# Altium schematic sheet Stepper Motor2.SchDoc
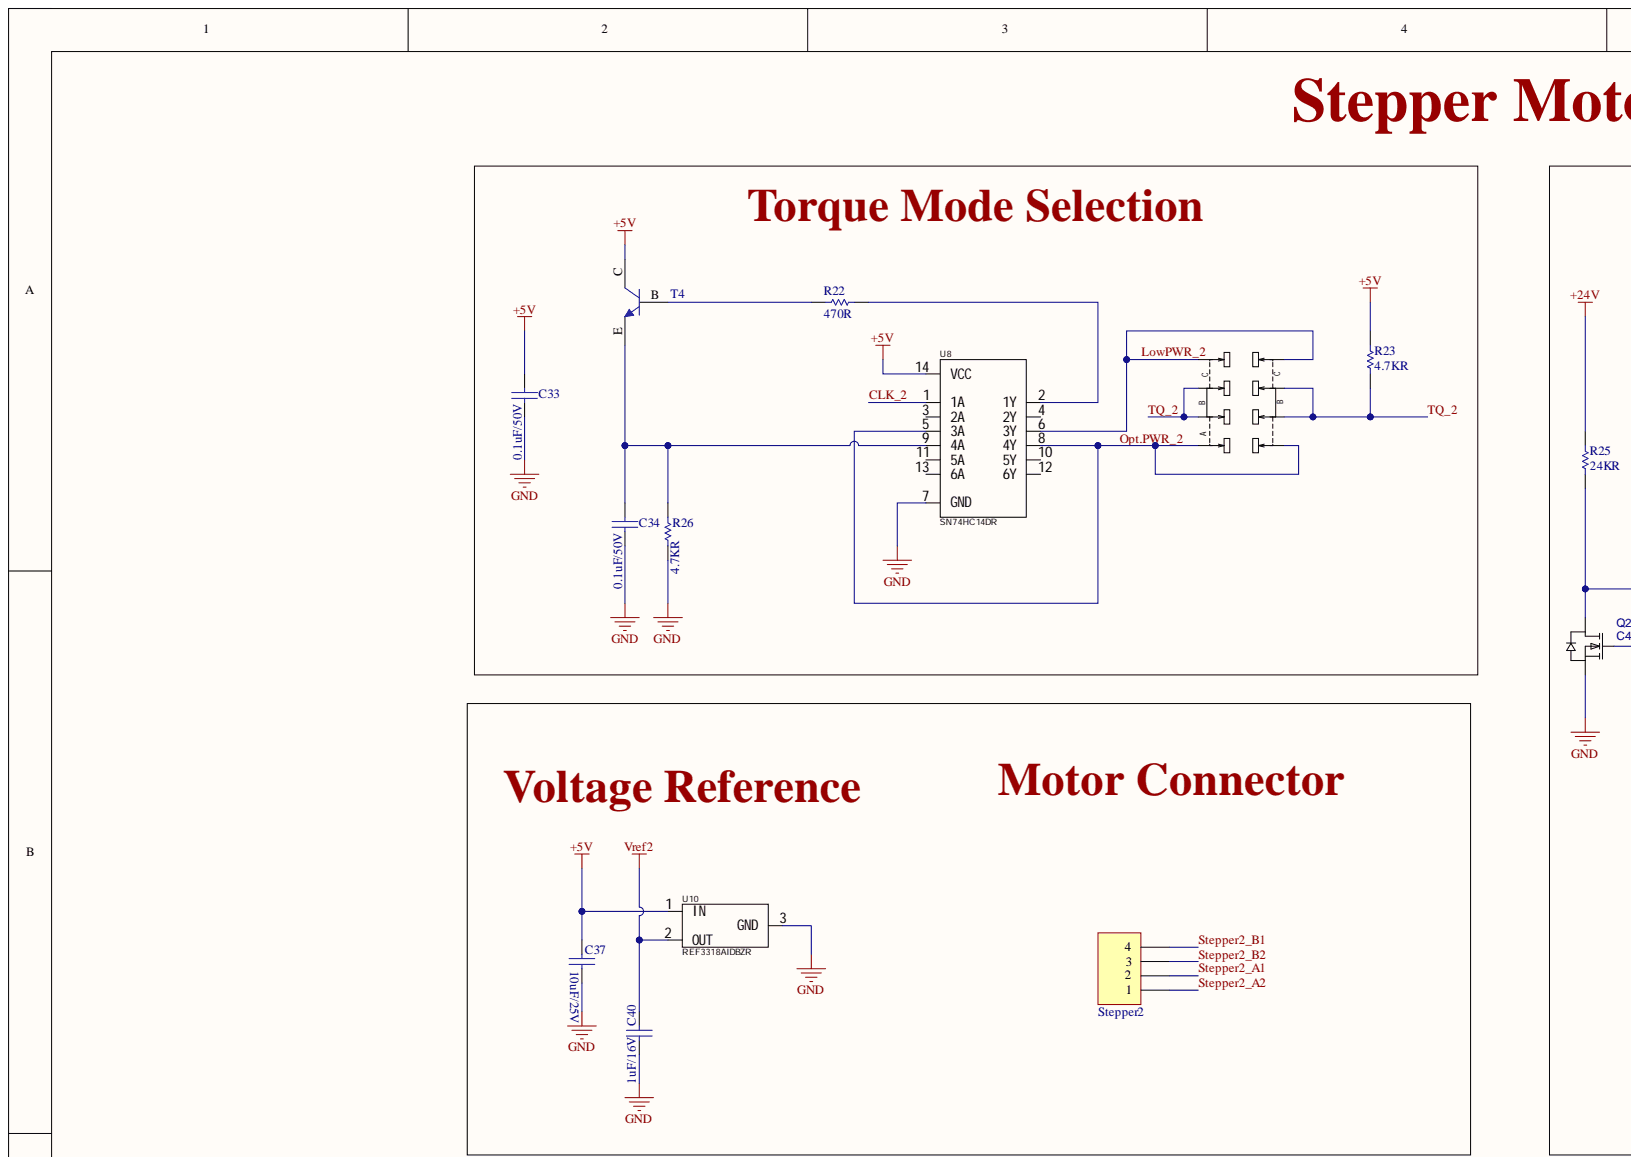
[diagram p0: Stepper Motor2.SchDoc, top left]
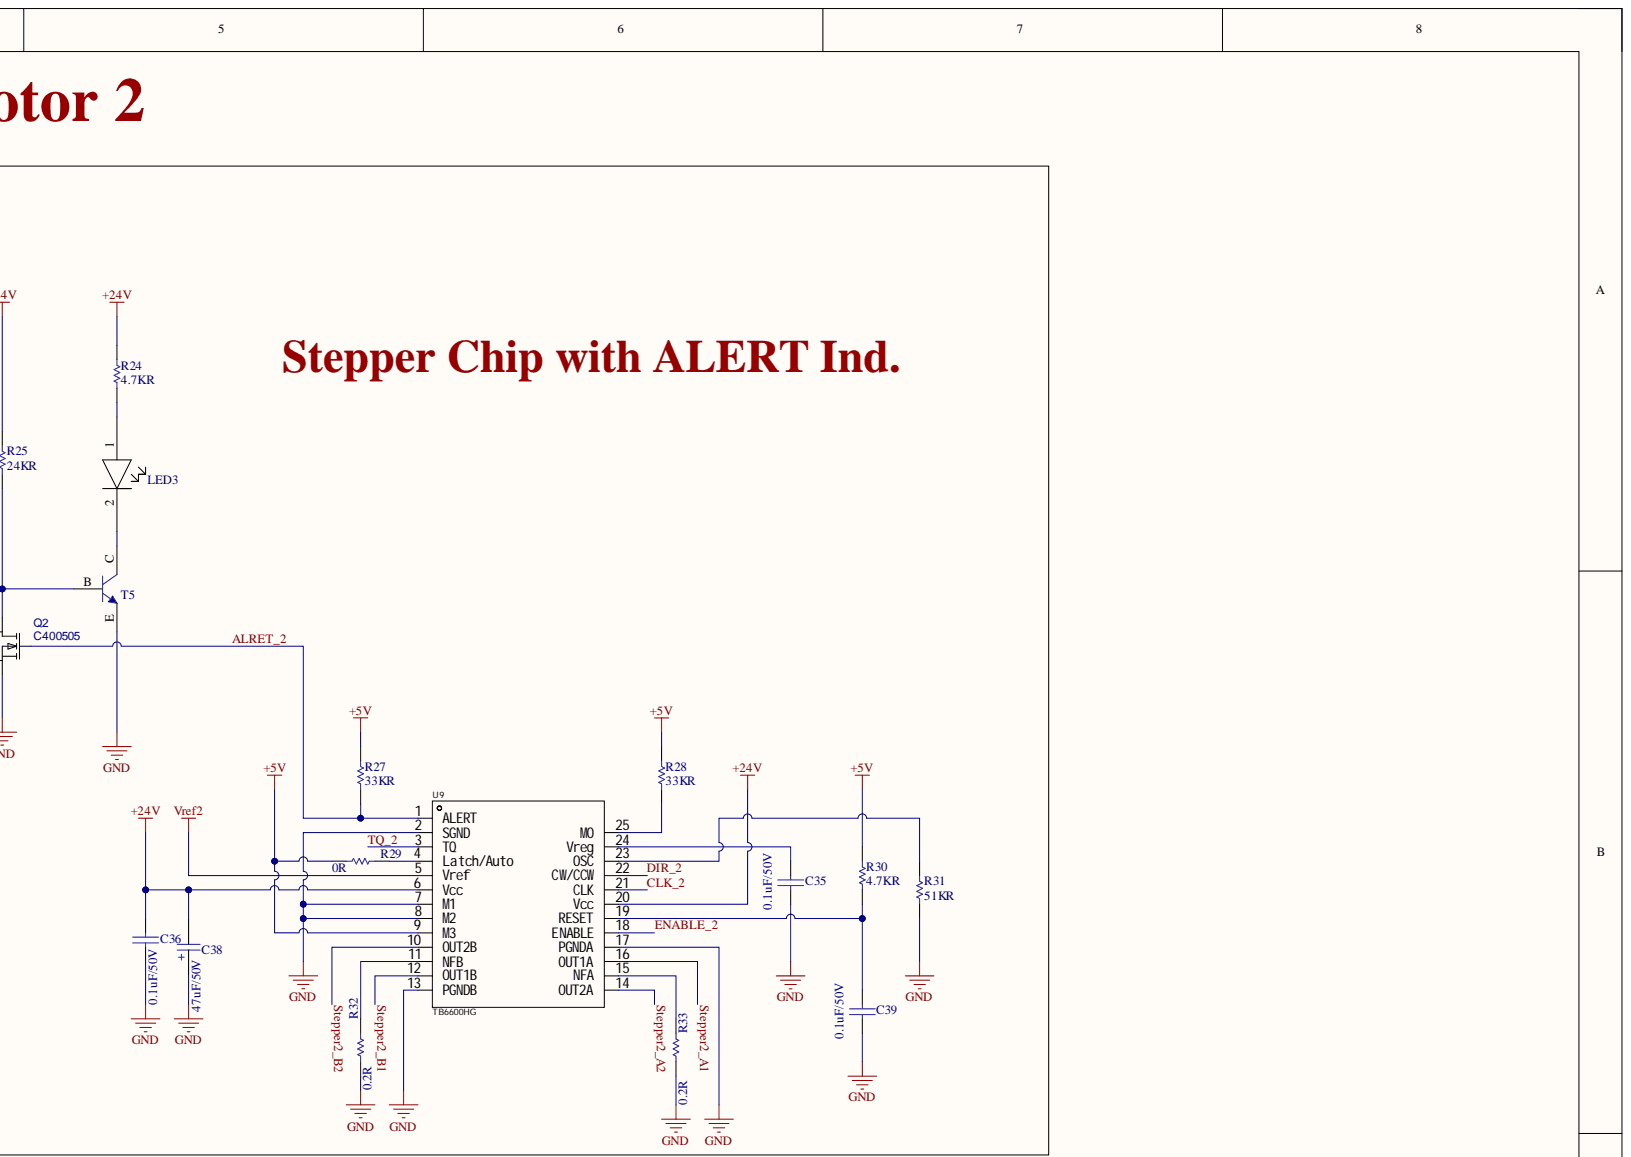
[diagram p1: Stepper Motor2.SchDoc, top right]
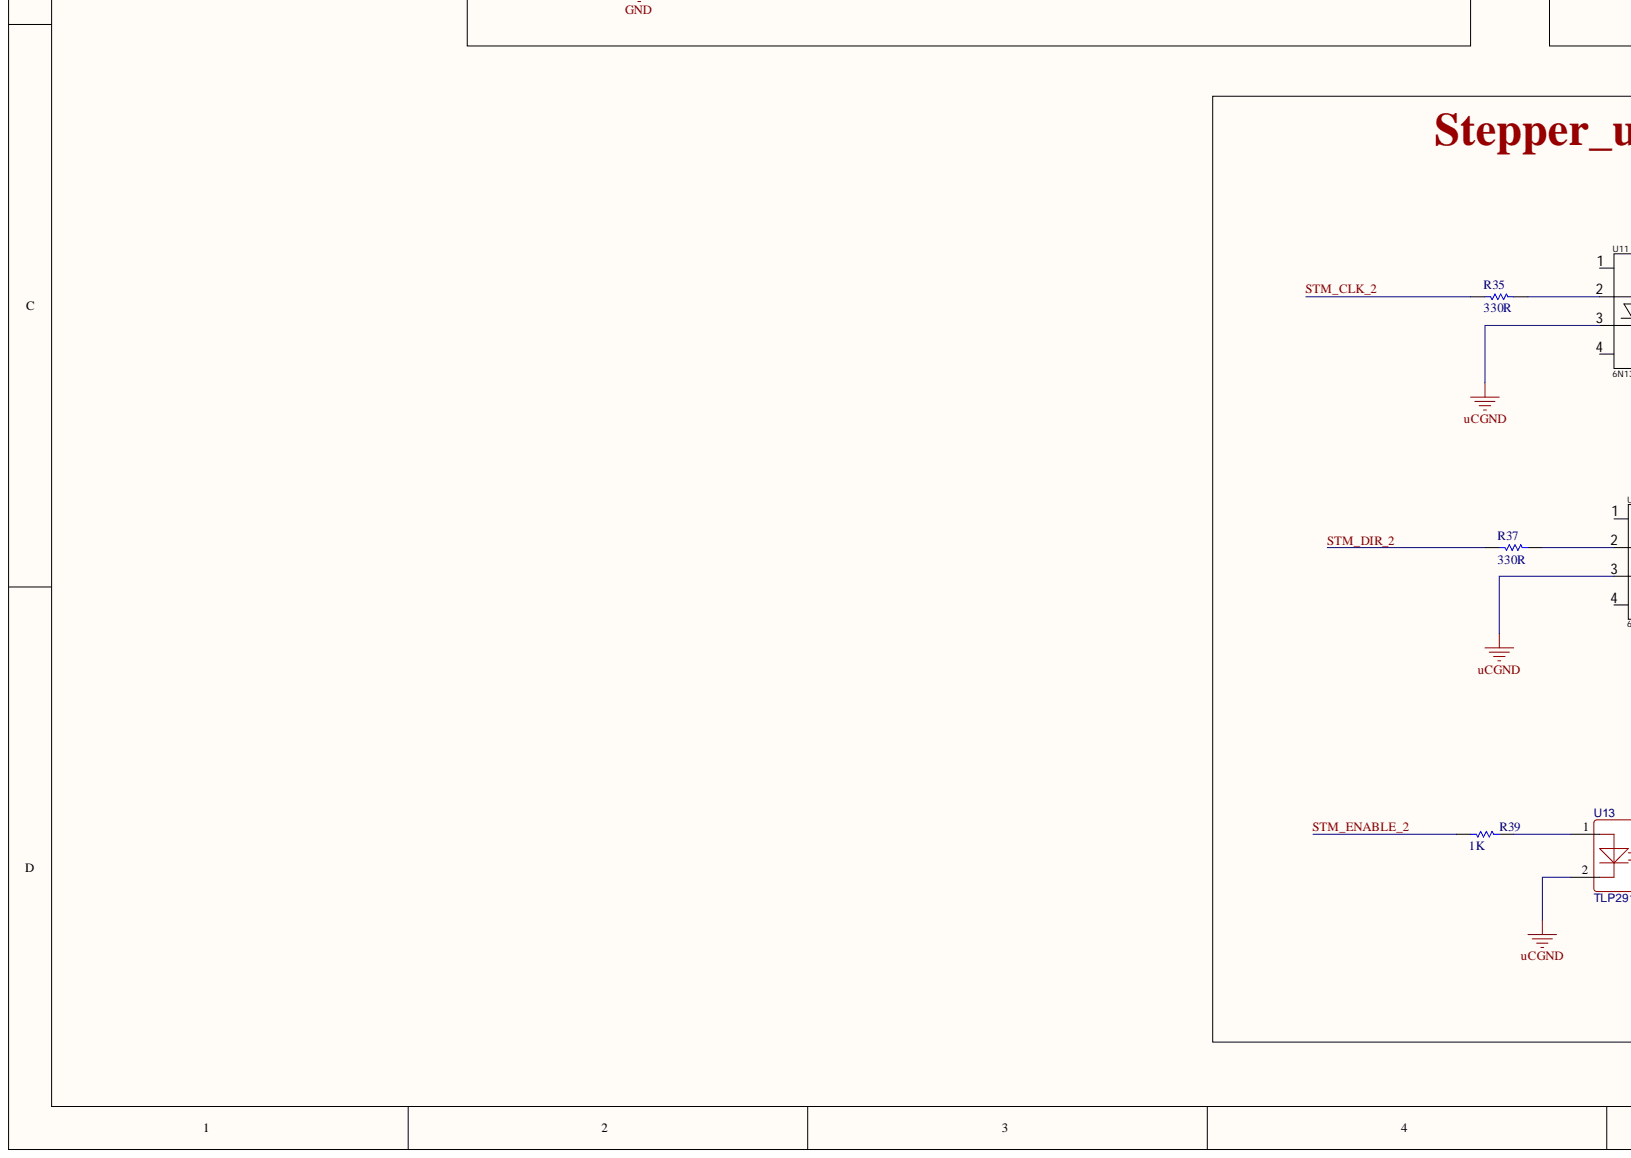
[diagram p2: Stepper Motor2.SchDoc, bottom left]
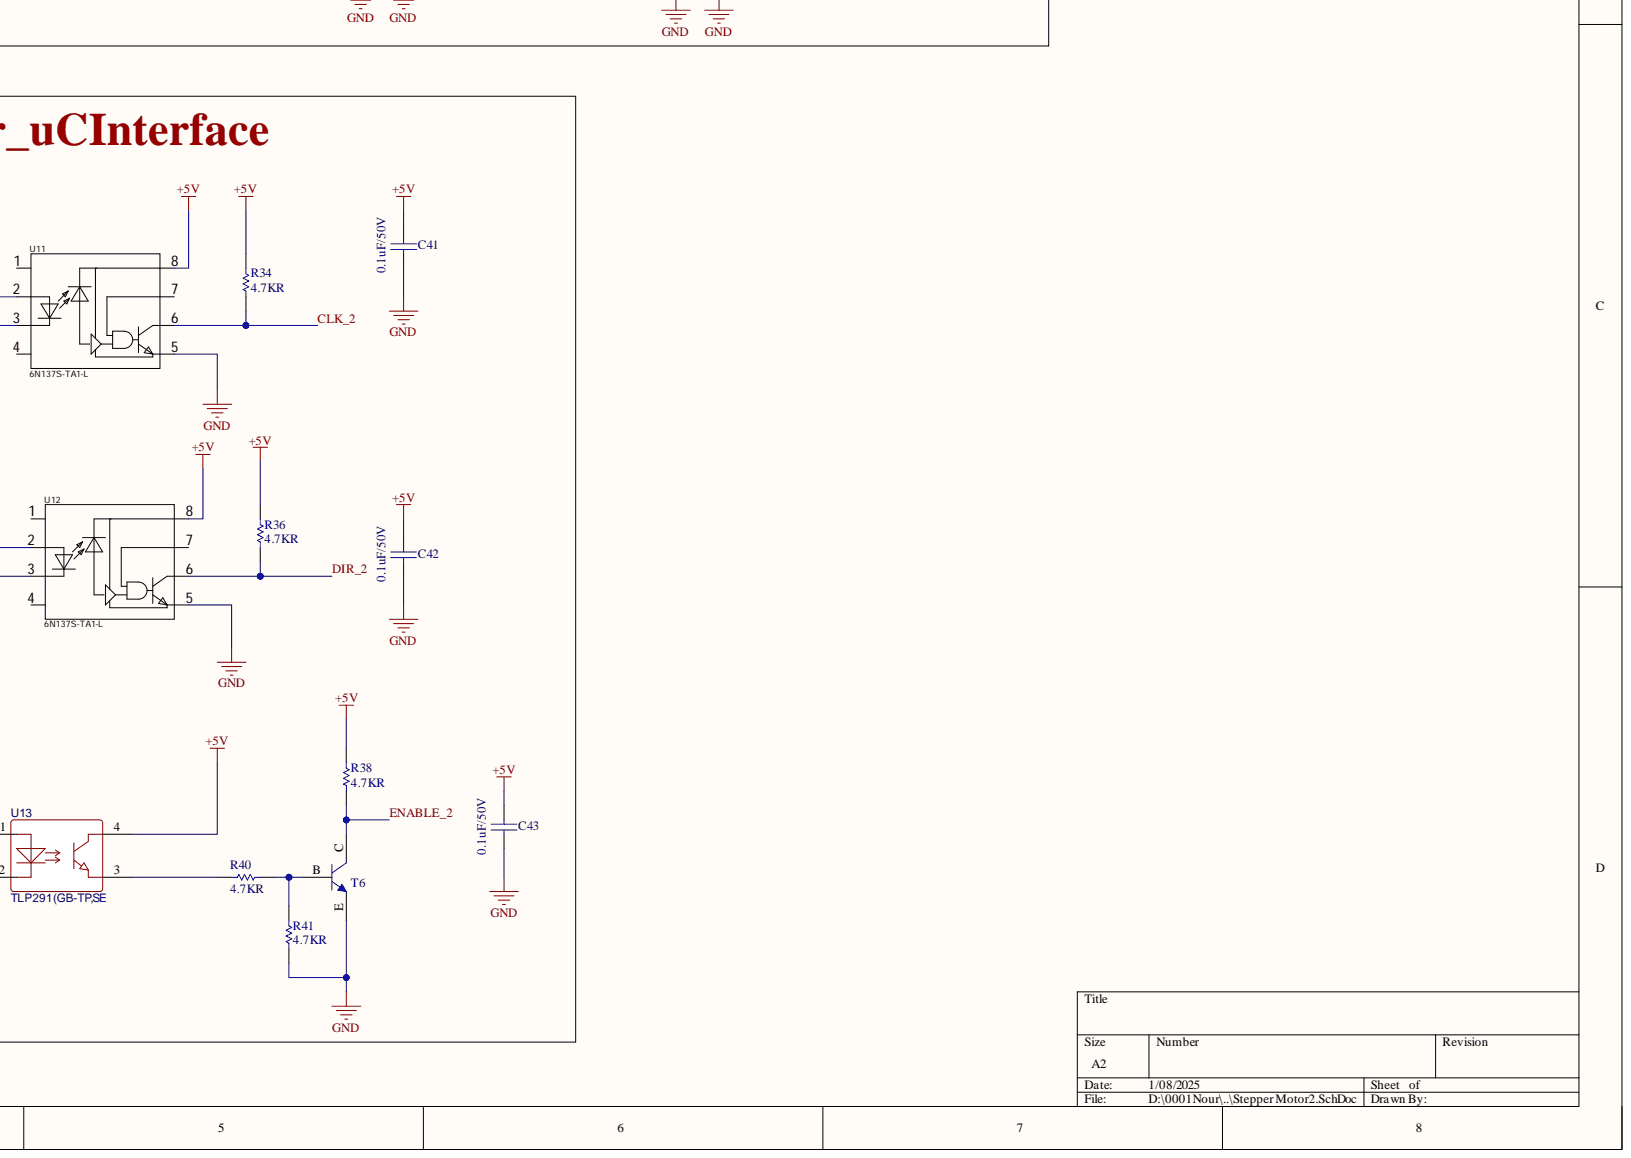
[diagram p3: Stepper Motor2.SchDoc, bottom right]

## Parts
C33  0.1uF/50V  [PCBComponent_1]
C34  0.1uF/50V  [PCBComponent_1]
C35  0.1uF/50V  [PCBComponent_1]
C36  0.1uF/50V  [PCBComponent_1]
C37  10uF/25V  [PCBComponent_1]
C38  47uF/50V  [CAPLEAD_D6.3mm]
C39  0.1uF/50V  [PCBComponent_1]
C40  1uF/16V  [PCBComponent_1]
C41  0.1uF/50V  [PCBComponent_1]
C42  0.1uF/50V  [PCBComponent_1]
C43  0.1uF/50V  [PCBComponent_1]
LED3  1206 LED  [1206 LED]  (value not drawn)
Q2  C400505  [SOT-23-3_L2.9-W1.3-P1.90-LS2.4-BR]
R22  470R  [RESC1608X06N]
R23  4.7KR  [R0402]
R24  4.7KR  [R0402]
R25  24KR  [RESC1608X06N]
R26  4.7KR  [R0402]
R27  33KR  [RESC1608X06N]
R28  33KR  [RESC1608X06N]
R29  0R  [RESC1608X06N]
R30  4.7KR  [R0402]
R31  51KR  [R0402]
R32  0.2R  [RESC6431X12N]
R33  0.2R  [RESC6431X12N]
R34  4.7KR  [R0402]
R35  330R  [R0402]
R36  4.7KR  [R0402]
R37  330R  [R0402]
R38  4.7KR  [R0402]
R39  1K  [R0402]
R40  4.7KR  [R0402]
R41  4.7KR  [R0402]
Stepper2  Header 4 Pin Polar  [PCBComponent_1]  (value not drawn)
SW2  MST23D19G2  [SW-SMD_MST23D19G2]  (value not drawn)
T4  MMBT3904 Transistor  [MMBT3904]  (value not drawn)
T5  MMBT3904 Transistor  [MMBT3904]  (value not drawn)
T6  MMBT3904 Transistor  [MMBT3904]  (value not drawn)
U8  SN74HC14DR  [SOIC-14_L8.7-W3.9-P1.27-LS6.0-BL]
U9  TB6600HG  [HZIP25-P-1.00F]
U10  REF3318AIDBZR  [SOT-23-3_L2.9-W1.3-P1.90-LS2.4-BR]
U11  6N137S-TA1-L  [SOP-8_L9.8-W6.6-P2.54-LS10.3-BL]
U12  6N137S-TA1-L  [SOP-8_L9.8-W6.6-P2.54-LS10.3-BL]
U13  TLP291(GB-TP,SE  [SOP-4-1.27mm]

## Nets
+5V: C33.2 C37.2 C41.2 C42.2 C43.2 R23.1 R27.2 R28.2 R29.2 R30.1 R34.1 R36.1 R38.1 T4.C U8.14(VCC) U9.9(M3) U10.1 U11.8 U12.8 U13.4
+24V: C36.2 C38.2 R24.1 R25.2 U9.6(Vcc) U9.20(Vcc)
GND: C33.1 C34.1 C35.1 C36.1 C37.1 C38.1 C39.1 C40.1 C41.1 C42.1 C43.1 Q2.2 R26.2 R31.2 R32.1 R33.1 R41.2 T5.E T6.E U8.7(GND) U9.2(SGND) U9.7(M1) U9.8(M2) U9.13(PGNDB) U9.17(PGNDA) U10.3 U11.5 U12.5
uCGND: U11.3 U12.3 U13.2
Vref2: C40.2 U9.5(Vref) U10.2
ALRET_2: Q2.1 R27.1 U9.1(ALERT)
CLK_2: R34.2 U8.1(1A) U9.21(CLK) U11.6
DIR_2: R36.2 U9.22(CW/CCW) U12.6
ENABLE_2: R38.2 T6.C U9.18(ENABLE)
LowPWR_2: SW2.4 SW2.5 U8.6(3Y)
Opt.PWR_2: SW2.1 SW2.8 U8.5(3A) U8.8(4Y)
Stepper2_A1: Stepper2.2 U9.16(OUT1A)
Stepper2_A2: Stepper2.1 U9.14(OUT2A)
Stepper2_B1: Stepper2.4 U9.12(OUT1B)
Stepper2_B2: Stepper2.3 U9.10(OUT2B)
STM_CLK_2: R35.2
STM_DIR_2: R37.2
STM_ENABLE_2: R39.2
TQ_2: R23.2 SW2.2 SW2.3 SW2.6 SW2.7 U9.3(TQ)
NetC34_2: C34.2 R26.1 T4.E U8.9(4A)
NetC35_2: C35.2 U9.24(Vreg)
NetC39_2: C39.2 R30.2 U9.19(RESET)
NetLED3_1: LED3.1 R24.2
NetLED3_2: LED3.2 T5.C
NetQ2_3: Q2.3 R25.1 T5.B
NetR22_1: R22.1 U8.2(1Y)
NetR22_2: R22.2 T4.B
NetR28_1: R28.1 U9.25(MO)
NetR29_1: R29.1 U9.4(Latch/Auto)
NetR31_1: R31.1 U9.23(OSC)
NetR32_2: R32.2 U9.11(NFB)
NetR33_2: R33.2 U9.15(NFA)
NetR35_1: R35.1 U11.2
NetR37_1: R37.1 U12.2
NetR39_1: R39.1 U13.1
NetR40_1: R40.1 R41.1 T6.B
NetR40_2: R40.2 U13.3

Not connected: U8 3(2A) 4(2Y) 10(5Y) 11(5A) 12(6Y) 13(6A); U11 1 4 7; U12 1 4 7

## Library data of the parts
C33-C36 C39 C41-C43 = 0603 Capacitor: C1591; Component Kind: Standard; DataSheet Link: https://eu.mouser.com/datasheet/2/400/lcc_automotive_general_en-843974.pdf; Library Name: 0603 Capacitor.IntLib; Mouser Link: https://eu.mouser.com/ProductDetail/TDK/CGA1A2X7R1H331M030BA?qs=sGAEpiMZZMs0AnBnWHyRQL8L3bkL%252bvtyS9XpSB1mk7rVV5K8%252bbxEeg%3d%3d; Created By: NourAldin
C37 = 0603 Capacitor: C96446; Component Kind: Standard; DataSheet Link: https://eu.mouser.com/datasheet/2/400/lcc_automotive_general_en-843974.pdf; Library Name: 0603 Capacitor.IntLib; Mouser Link: https://eu.mouser.com/ProductDetail/TDK/CGA1A2X7R1H331M030BA?qs=sGAEpiMZZMs0AnBnWHyRQL8L3bkL%252bvtyS9XpSB1mk7rVV5K8%252bbxEeg%3d%3d; Created By: NourAldin
C38 = A759EQ475M2AAAE160: C45100; Manufacturer: KEMET; Category: Polymer Capacitors; Component Kind: Standard; ComponentLink1Description: http://www.kemet.com/docfinder?Partnumber=A759EQ475M2AAAE160&src-supplier=Digikey; ComponentLink1URL: http://www.kemet.com/docfinder?Partnumber=A759EQ475M2AAAE160&src-supplier=Digikey; ComponentLink2Description: http://www.farnell.com/datasheets/2095614.pdf?src-supplier=Element14; ComponentLink2URL: http://www.farnell.com/datasheets/2095614.pdf?src-supplier=Element14; Library Name: GlobalLib.IntLib; Manufacturer Part Number: A759EQ475M2AAAE160; Pricing 1: 1=0.97, 10=0.73, 50=0.73, 100=0.546, 1000=0.384, 10000=0.326 (USD); RoHS: Yes; Stock 1: 17219; Supplier 1: Mouser; Supplier Part Number 1: 80-A759EQ475M2AAE160
C40 = 0603 Capacitor: C1592; Component Kind: Standard; DataSheet Link: https://eu.mouser.com/datasheet/2/400/lcc_automotive_general_en-843974.pdf; Library Name: 0603 Capacitor.IntLib; Mouser Link: https://eu.mouser.com/ProductDetail/TDK/CGA1A2X7R1H331M030BA?qs=sGAEpiMZZMs0AnBnWHyRQL8L3bkL%252bvtyS9XpSB1mk7rVV5K8%252bbxEeg%3d%3d; Created By: NourAldin
Q2 = BSS138_MOSFET: Manufacturer: YANGJIE(扬杰); Manufacturer Part: BSS138; Contributor: LCSC; Component Kind: Standard; Description: C400505; JLCPCB Part Class: Extended Part; spicePre: Q; spiceSymbolName: BSS138_C400505; Supplier: LCSC; Supplier Part: C400505; Library Name: DIVERSE.IntLib
R22 R25 R27-R29 = 0603 Resistor: Component Kind: Standard; DataSheet Link: https://eu.mouser.com/datasheet/2/315/AOA0000C307-1149632.pdf; Description: 0603 Resistor; Library Name: 0603 Resistor.IntLib; Mouser Link: https://eu.mouser.com/ProductDetail/Panasonic/ERA-3AHD180V?qs=sGAEpiMZZMvdGkrng054t5mej2KPdPuFSlDBd1tSiF0%3d; Created By: NourAldin
R23 R24 R26 R30 R31 R34 R36 R38 R40 R41 = 0402 Resistor: C105871; Component Kind: Standard; Library Name: DIVERSE.IntLib
R32 R33 = 2512 Resistor: C2903473; Component Kind: Standard; DataSheet Link: https://eu.mouser.com/datasheet/2/54/ss2h-2512-1302652.pdf; Library Name: 2512 Resistor.IntLib; Mouser Link: https://eu.mouser.com/ProductDetail/Bourns/CSS2H-2512K-2L00F?qs=sGAEpiMZZMukHu%252bjC5l7YewuupLM8jZrvWs15Y6t0rY%3D&fbclid=IwAR0ZD_uc1Y03YG_KyYG8NxrpBzYgFzVeevvjxrlqtGWJ1HKz-jrYfqlFWCw; Created By: NourAldin
R35 R37 = 0402 Resistor: C105875; Component Kind: Standard; Library Name: DIVERSE.IntLib
R39 = 0402 Resistor: C106235; Component Kind: Standard; Library Name: DIVERSE.IntLib
SW2 = MST23D19G2: Manufacturer: SHOU HAN(首韩); Add into BOM: yes; Circuit: 双刀三掷; Current Rating (DC): 100mA; Mechanical Life: 1万次; Pin Style: SMDSplicing; Switch Height: 3.5mm; Switch Length: 12.95mm; Switch Width: 3.55mm; Voltage Rating (DC): 12V; Actuator Color: -; Actuator Style: -; Component Kind: Standard; Convert to PCB: yes; Current Rating (AC): -; Datasheet: https://atta.szlcsc.com/upload/public/pdf/source/20190925/C431545_A88710AC241B275593C257CF6D595AD7.pdf; Device: MST23D19G2; JLCPCB Part Class: Extended Part; LCSC Part Name: 三档立式贴片 8脚拨动 柄长2.0MM; Library Name: LIB.SchLib; Manufacturer Part: MST23D19G2; Mounting Style: Brick nogging; Operating Temperature: -25℃~+70℃; Supplier: LCSC; Supplier Footprint: SMD; Supplier Part: C431545; Symbol: MST23D19G2; Voltage Rating (AC): -
U8 = SN74HC14DR: C6820; Manufacturer: TI(德州仪器); Add into BOM: yes; High level range (VIH): 1.5V~4.2V; Input Type: Schmitt Trigger; Low level range (VIL): 300mV~1.2V; Max Propagation Delay: 21ns@6V,50pF; Number of Circuits: 6; Number of Inputs: 1; Sink Current: 5.2mA; Supply Current (Max): 2uA; Supply Voltage(Single): 2V~6V; Component Kind: Standard; Convert to PCB: yes; Datasheet: https://www.ti.com/cn/lit/gpn/sn74hc14; Device: SN74HC14DR; JLCPCB Part Class: Extended Part; LCSC Part Name: 六路施密特触发反相器; Library Name: LIB.SchLib; Manufacturer Part: SN74HC14DR; Operating Temperature: -40℃~+85℃; Series: 74HCSeries; Source Current: 5.2mA; Supplier: LCSC; Supplier Footprint: SOIC-14; Supplier Part: C6820; Symbol: SN74HC14DR
U9 = TB6600HG: C66042; Manufacturer: TOSHIBA(东芝); Add into BOM: yes; Component Kind: Standard; Convert to PCB: yes; Datasheet: https://atta.szlcsc.com/upload/public/pdf/source/20170511/1494500127812.pdf; Device: TB6600HG; JLCPCB Part Class: Extended Part; LCSC Part Name: 4.5A 42V电机驱动芯片; Library Name: LIB.SchLib; Manufacturer Part: TB6600HG; Supplier: LCSC; Supplier Footprint: HZIP-25-P-1mm; Supplier Part: C66042; Symbol: TB6600HG
U10 = REF3318AIDBZR: C115877; Manufacturer: TI(德州仪器); Add into BOM: yes; Input Voltage: 2V~5.5V; Noise - 1/10Hz to 10Hz: 50uVp-p; Output Current: 5mA; Output Type: Fixed; Output Voltage: 1.8V; Reference Type: series connection; Supply Current: 8.5uA; Temperature Coefficient: 30ppm/℃; Tolerance: ±0.15%; Component Kind: Standard; Convert to PCB: yes; Datasheet: https://www.ti.com/cn/lit/gpn/ref3318; Device: REF3318AIDBZR; JLCPCB Part Class: Extended Part; LCSC Part Name: REF3318AIDBZR; Library Name: LIB.SchLib; Manufacturer Part: REF3318AIDBZR; Noise - 10Hz to 10kHz: -; Operating Temperature: -40℃~+125℃@(Ta); Supplier: LCSC; Supplier Footprint: SOT-23; Supplier Part: C115877; Symbol: REF3318AIDBZR
U11 U12 = 6N137S-TA1-L: C92651; Manufacturer: LITEON(光宝); Add into BOM: yes; Common Mode Transient Immunity (CMTI): 10kV/us; Data Rate: 15Mbit/s; Input Type: DC; Number of Channels: 1; Propagation Delay tpLH/tpHL: 32ns；40ns; Supply Voltage: 4.5V~5.5V; Component Kind: Standard; Convert to PCB: yes; Datasheet: https://atta.szlcsc.com/upload/public/pdf/source/20191018/C92651_D1F9F6F1F10C7EC7C3739E5ECB700036.pdf; Device: 6N137S-TA1-L; JLCPCB Part Class: Extended Part; LCSC Part Name: DC输入 1通道; Library Name: LIB.SchLib; Manufacturer Part: 6N137S-TA1-L; Operating Temperature: -40℃~+85℃; Supplier: LCSC; Supplier Footprint: SOP-8-2.54mm; Supplier Part: C92651; Symbol: 6N137S-TA1
U13 = TLP291(GB-TP,SE(T: C55144; BOM_Manufacturer: TOSHIBA(东芝); BOM_JLCPCB Part Class: Extended Part; BOM_Supplier: LCSC; Component Kind: Standard; LCSC Link: https://www.lcsc.com/product-detail/Optocouplers-Phototransistor-Output_TOSHIBA-TLP291-GB-TP-SE_C55144.html; Library Name: CO2_Lib.IntLib; Manufacturer Part Number: TLP291(GB-TP,SE; spiceSymbolName: TLP291(GB-TP,SE(T; Supplier Part Number 2: C55144
LED3 = 1206 LED: Component Kind: Standard; Description: 1206 LED; Library Name: 1206 LED.IntLib; Created By: NourAldin
Stepper2 = Header 4 Pin Polar: Component Kind: Standard; Description: Header 4 Pin Polar; Library Name: Header 4 Pin Polar.IntLib
T4-T6 = MMBT3904 Transistor: Component Kind: Standard; Description: MMBT3904 Transistor; Library Name: MMBT3904 Transistor.IntLib; Created By: NourAldin
